# Altium schematic sheet LampOne.SchDoc(LampOne)
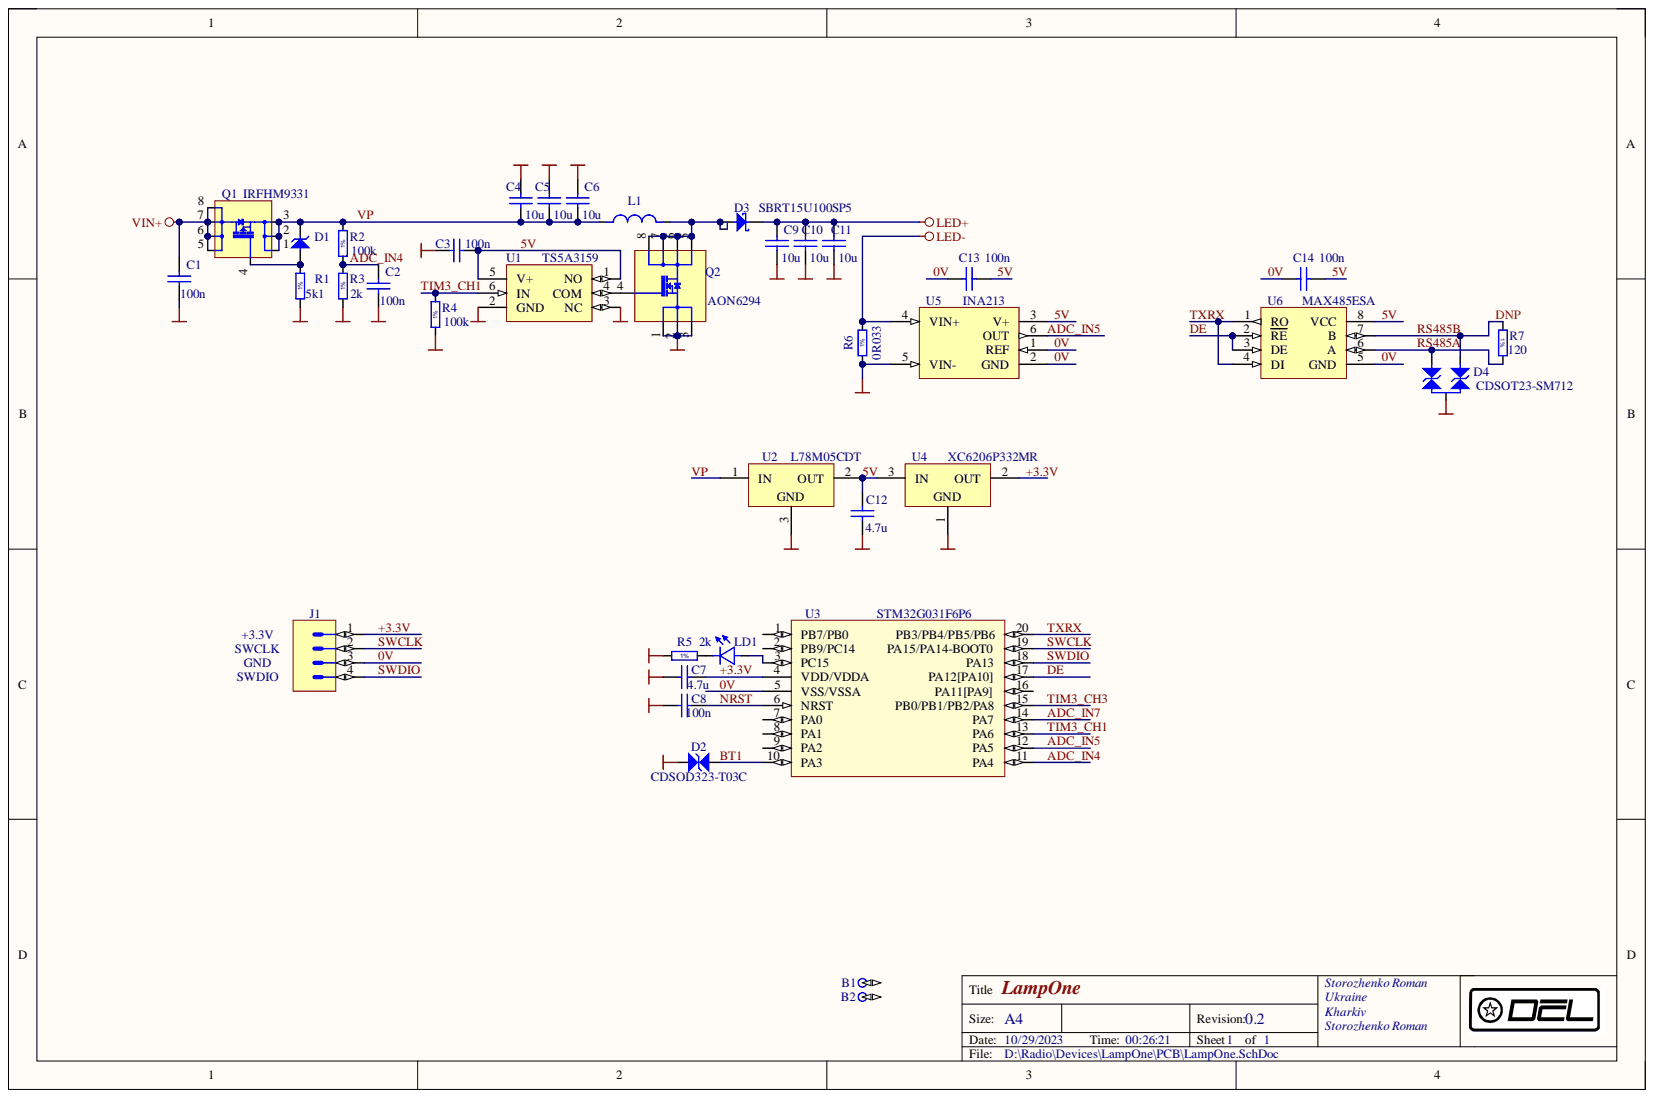
[diagram p0: LampOne.SchDoc]

## Parts
B1  Non Plated Hole  [MTG600H320V8P]  (value not drawn)  (no BOM)
B2  Non Plated Hole  [MTG600H320V8P]  (value not drawn)  (no BOM)
C1  100n  [CAPC1608X87N]
C2  100n  [CAPC1608X87N]
C3  100n  [CAPC1608X87N]
C4  10u  [CAPC3225X270N]
C5  10u  [CAPC3225X270N]
C6  10u  [CAPC3225X270N]
C7  4.7u  [CAPC1608X87N]
C8  100n  [CAPC1608X87N]
C9  10u  [CAPC3225X270N]
C10  10u  [CAPC3225X270N]
C11  10u  [CAPC3225X270N]
C12  4.7u  [CAPC1608X87N]
C13  100n  [CAPC1608X87N]
C14  100n  [CAPC1608X87N]
D1  BZV55-B10  [DIOMELF3515N]  (value not drawn)
D2  CDSOD323-T03C  [SOD2512X110N]
D3  SBRT15U100SP5  [SBRT15U100SP5]
D4  CDSOT23-SM712  [SOT95P240X130-3N]
J1  Header 4  [3M_2304-6111-TG]  (value not drawn)
L1  10uH  [IND_BOURNS_PM127SH]  (value not drawn)
LD1  Red  [LEDC1608X80N_RED]  (value not drawn)
Q1  IRFHM9331  [PQFN50P300X300X100_HS-9N]
Q2  AON6294  [DFN-5X6-8L]
R1  5k1  [RESC1608X55N]
R2  100k  [RESC1608X55N]
R3  2k  [RESC1608X55N]
R4  100k  [RESC1608X55N]
R5  2k  [RESC1608X55N]
R6  0R033  [RESC3216X80N]
R7  120  Mounted: DNP  [RESC1005X40N]
U1  TS5A3159  [SOT65P210X110-6N]
U2  L78M05CDT  [TO228P1010X239-3N-R521X544]
U3  STM32G031F6P6  [SOP65P640X110-20N]
U4  XC6206P332MR  [SOT95P280X115-3N]
U5  INA213  [SOT65P210X110-6N]
U6  MAX485ESA  [SOIC127P600X175-8N]

## Nets
LED+: C9.2 C10.2 C11.2 D3.3
LED-: R6.2 U5.4(VIN+)
VIN+: C1.2 Q1.5 Q1.6 Q1.7 Q1.8
0V: C1.1 C2.1 C3.2 C4.1 C5.1 C6.1 C7.1 C8.1 C9.1 C10.1 C11.1 C12.1 C13.2 C14.2 D2.2 D4.3 J1.3 Q2.1 Q2.2 Q2.3 R1.1 R3.1 R4.1 R5.1 R6.1 U1.2(GND) U1.3(NC) U2.3 U3.5(VSS/VSSA) U4.1 U5.1(REF) U5.2(GND) U5.5(VIN-) U6.5(GND)
5V: C3.1 C12.2 C13.1 C14.1 U1.1(NO) U1.5(V+) U2.2 U4.3 U5.3(V+) U6.8(VCC)
+3.3V: C7.2 J1.1 U3.4(VDD/VDDA) U4.2
ADC_IN4: C2.2 R2.1 R3.2 U3.11(PA4)
ADC_IN5: U3.12(PA5) U5.6(OUT)
ADC_IN7: U3.14(PA7)
BT1: D2.1 U3.10(PA3)
DE: U3.17(PA12[PA10]) U6.2(RE) U6.3(DE)
NRST: C8.2 U3.6(NRST)
RS485A: D4.2 R7.2 U6.6(A)
RS485B: D4.1 R7.1 U6.7(B)
SWCLK: J1.2 U3.19(PA15/PA14-BOOT0)
SWDIO: J1.4 U3.18(PA13)
TIM3_CH1: R4.2 U1.6(IN) U3.13(PA6)
TIM3_CH3: U3.15(PB0/PB1/PB2/PA8)
TXRX: U3.20(PB3/PB4/PB5/PB6) U6.1(RO) U6.4(DI)
VP: C4.2 C5.2 C6.2 D1.1 L1.1 Q1.1 Q1.2 Q1.3 R2.2 U2.1
NetD1_2: D1.2 Q1.4 R1.2
NetD3_1: D3.1 D3.2 L1.2 Q2.5 Q2.6 Q2.7 Q2.8
NetLD1_1: LD1.1 R5.2
NetLD1_2: LD1.2 U3.3(PC15)
NetQ2_4: Q2.4 U1.4(COM)

Not connected: B1 1; B2 1; U3 1(PB7/PB0) 2(PB9/PC14) 7(PA0) 8(PA1) 9(PA2) 16(PA11[PA9])

## Library data of the parts
B1 B2 = NON-PLATED_HOLE3.2_PAD6.0_8p: Single Terminal Socket; Part Description: Through Hole: Hole Dia.=3.2mm Pad Dia.=6mm + 6 Via Dia.=0.3mm; Database Table Name: Pads; Mounted: No; Component Type: Standard (No BOM); ComponentHeight: 0mm; CreateDate: 11/21/2022; Family: Non Plated Hole; LatestRevisionDate: 11/21/2022; Library Name: Eletro-mechanical.DbLib; PackageDescription: Non Plated Through Hole: Hole Dia.=3.2mm; Part Number: NON-PLATED_HOLE3.2_PAD6.0_8p; Pin Count: 1; SMD: No; Socket: No; Status: None; Author: DEL
C1-C3 C8 C13 C14 = CC0603_100NF_50V_10%_X7R: Non-polarised capacitor; Manufacturer: GENERIC; Part Description: SMD Multilayer Chip Ceramic Capacitor; Database Table Name: Capacitors SMD; TC: X7R; Tolerance: ±10%; Voltage: 50V; Case: 0603; Component Kind: Standard; Component Type: Standard; CreateDate: 7/14/2014; LatestRevisionDate: 7/14/2014; Library Name: Capacitors.DbLib; Manufacturer1 ComponentHeight: 0.87mm; Manufacturer Part Number: CC0603_100NF_50V_10%_X7R; Mounted: Yes; Part Number: CC0603_100NF_50V_10%_X7R; Pin Count: 2; SMD: Yes; Socket: No; Status: Preferred; Value: 100n; Author: Elman
C4-C6 C9-C11 = CC1210_10UF_25V_10%_X7R: Non-polarised capacitor; Manufacturer: GENERIC; Manufacturer1 Part Number: C1210C106K3RAC; Manufacturer Part Number: CC1210_10UF_50V_10%_X7R; Part Description: SMD Multilayer Chip Ceramic Capacitor; Database Table Name: Capacitors SMD; TC: X7R; Tolerance: ±10%; Voltage: 50V; Case: 1210; Component Kind: Standard; Component Type: Standard; CreateDate: 5/5/2009; HelpURL: \\cern.ch\dfs\Applications\Altium\Datasheets\CC1210_X7R_KEMET.pdf; LatestRevisionDate: 4/1/2010; Library Name: Capacitors.DbLib; Manufacturer1 ComponentHeight: 2.7mm; Manufacturer1 Example: KEMET; Mounted: Yes; Part Number: CC1210_10UF_50V_10%_X7R; Pin Count: 2; SMD: Yes; Socket: No; Status: None; Value: 10u; Author: CERN DEM JLC
C7 C12 = CC0603_4.7UF_10V_10%_X5R: Non-polarised capacitor; Manufacturer: GENERIC; Manufacturer1 Part Number: C1608X5R1A475K080AC; Part Description: SMD Multilayer Chip Ceramic Capacitor; Database Table Name: Capacitors SMD; TC: X5R; Tolerance: ±10%; Voltage: 10V; Case: 0603; Component Kind: Standard; Component Type: Standard; CreateDate: 11/13/2016; LatestRevisionDate: 11/13/2016; Library Name: Capacitors.DbLib; Manufacturer1 ComponentHeight: 0.95mm; Manufacturer1 Example: TDK; Manufacturer Part Number: CC0603_4.7uF_10V_10%_X5R; Mounted: Yes; Part Number: CC0603_4.7UF_10V_10%_X5R; Pin Count: 2; SMD: Yes; Socket: No; Status: Preferred; Value: 4.7u; Author: ELMAN
D1 = BZV55-B10: Zener Diode; Manufacturer: PHILIPS NXP; Part Description: Voltage Regulator Diode; Database Table Name: Diodes; Power: 500mW; Voltage: 10V; Case: SOD-80C; Component Kind: Standard; Component Type: Standard; ComponentHeight: 1.6mm; CreateDate: 5/6/2008; Device: BZV55-B10; HelpURL: \\cern.ch\dfs\Applications\Altium\Datasheets\BZV55.pdf; LatestRevisionDate: 5/6/2008; Library Name: ICs And Semiconductors.DbLib; Manufacturer Part Number: BZV55-B10; Mounted: Yes; PackageDescription: SOD80C, MELF Diode, 2-Leads, Body 3.5x1.5mm, IPC Medium Density; Part Number: BZV55-B10; Pin Count: 2; SMD: Yes; Socket: No; Author: CERN DEM JLC
D2 = CDSOD323-T03C: Transient Voltage Suppressor Diode (Bi-Directional); Manufacturer: BOURNS; Part Description: TVS Diode; Database Table Name: Diodes; Power: 20A; Voltage: 4V; Case: SOD323; Component Kind: Standard; Component Type: Standard; ComponentHeight: 1.1mm; CreateDate: 11/21/2022; Device: CDSOD323-T03C; LatestRevisionDate: 11/21/2022; Library Name: ICs And Semiconductors.DbLib; Manufacturer Part Number: CDSOD323-T03C; Mounted: Yes; PackageDescription: SOD-323, 1.70mm W X 1.25mm L X 1.10mm H; Part Number: CDSOD323-T03C; Pin Count: 3; SMD: Yes; Socket: No; Author: DEL
D3 = SBRT15U100SP5: Diode  Schottky Anode pin 1 and 2 cathode pin 3; Part Description: Schottky Rectifier; Database Table Name: Diodes; Power: 15A; Voltage: 100V; Case: DFN; Component Kind: Standard; Component Type: Standard; ComponentHeight: 1.15mm; CreateDate: 6/24/2022; Device: SBRT15U100SP5; LatestRevisionDate: 6/24/2022; Library Name: ICs And Semiconductors.DbLib; Manufacturer: DIODES; Manufacturer Part Number: SBRT15U100SP5; Mounted: Yes; PackageDescription: DFN, 3-Leads, Body 4.05x6.6mm; Part Number: SBRT15U100SP5; Pin Count: 3; SMD: Yes; Socket: No; Author: DEL
D4 = CDSOT23-SM712: Transient Voltage Suppressor Diode (Bi-Directional); Manufacturer: BOURNS; Part Description: TVS Diode; Database Table Name: Diodes; Power: 17A; Voltage: 7.5V; Case: SOT23-3; Component Kind: Standard; Component Type: Standard; ComponentHeight: 1.3mm; CreateDate: 1/27/2022; Device: CDSOT23-SM712; LatestRevisionDate: 1/27/2022; Library Name: ICs And Semiconductors.DbLib; Manufacturer Part Number: CDSOT23-SM712; Mounted: Yes; PackageDescription: SOT23 3-Leads, Pitch 0.95mm - Body 1.3x2.9mm, IPC Medium Density; Part Number: CDSOT23-SM712; Pin Count: 3; SMD: Yes; Socket: No; Author: DEL
J1 = 3M_2304-6111-TG: Connector 4 Male; Part Description: 4 Contacts - Vertival Contacts Straight Strip Header Pitch 2.54; Database Table Name: 3M; Component Kind: Standard; Component Type: Standard; ComponentHeight: 8.4mm; CreateDate: 2018-09-13; Family: Header 4; LatestRevisionDate: 2018-09-13; Library Name: Connectors.DbLib; Manufacturer: 3M; Manufacturer Part Number: 3M_2304-6111-TG; Mounted: Yes; Part Number: 3M_2304-6111-TG; Pin Count: 4; SMD: No; Socket: No; Status: None; Author: DEL
L1 = IND_4.7UH_20%_SUMIDA_CDRH124: Inductor; Manufacturer: SUMIDA; Manufacturer Part Number: CDRH125NP-10R; Part Description: Shielded SMD Power Inductors CDRH125 Series; Part Number: IND_10UH_20%_SUMIDA_CDRH125; Database Table Name: Inductors SMD; Power: 4A; Resistance: 0.018R; Tolerance: ±20%; Component Kind: Standard; Component Type: Standard; ComponentHeight: 4.5mm; CreateDate: 11/18/2009; HelpURL: \\cern.ch\dfs\Applications\Altium\Datasheets\IND_BOURNS_PM127SH.pdf; LatestRevisionDate: 11/18/2009; Library Name: Inductors & Transformers.DbLib; Mounted: Yes; Pin Count: 2; SMD: Yes; Socket: No; Status: None; Value: 10uH; Author: CERN DEM JLC
LD1 = LED_ROHM_SML-311UTT86K: LED 1C 2A; Manufacturer: ROHM; Part Description: Low current chip LED SML-311 Serie; Database Table Name: LEDs & Displays; Color: Red; Component Kind: Standard; Component Type: Standard; ComponentHeight: 0.8mm; CreateDate: 3/16/2010; HelpURL: \\cern.ch\dfs\Applications\Altium\Datasheets\LED_ROHM_SML-311UTT86K.pdf; LatestRevisionDate: 3/17/2010; Library Name: ICs And Semiconductors.DbLib; Manufacturer Part Number: SML-311UTT86K; Mounted: Yes; PackageDescription: Chip Red LED, 2-Leads, Body 1.6x0.8mm, IPC Medium Density; Part Number: LED_ROHM_SML-311UTT86K; Pin Count: 2; SMD: Yes; Socket: No; Author: CERN DEM JLC
Q1 = IRFHM9331TRPbF: P-CHANNEL MOSFET WITH DIODE; Manufacturer: INFINEON; Part Description: -30V 11A 0.014 Ohm P-Channel  MOSFET; Database Table Name: Transistors; Case: PQFN; Component Kind: Standard; Component Type: Standard; ComponentHeight: 1mm; CreateDate: 29.01.2018; Device: IRFHM9331; LatestRevisionDate: 29.01.2018; Library Name: ICs And Semiconductors.DbLib; Manufacturer Part Number: IRFHM9331TRPbF; Mounted: Yes; PackageDescription: PQFN, 8-Leads, Body 3,00x3,00mm, Pitch 0,50mm, Thermal Pad 4,00x2,20mm, IPC Medium Density; Part Number: IRFHM9331TRPbF; Pin Count: 8; SMD: Yes; Socket: No; Author: DEL
Q2 = AON6294: Manufacturer: LPHA & OMEGA SEMICONDUCTOR; Part Description: 100V N-Channel AlphaMOS; Database Table Name: Transistors; Case: DFN-5X6; Component Kind: Standard; Component Type: Standard; ComponentHeight: 1mm; CreateDate: 6/24/2022; Device: AON6294; LatestRevisionDate: 6/24/2022; Library Name: ICs And Semiconductors.DbLib; Manufacturer Part Number: AON6294; Mounted: Yes; PackageDescription: DFN 5x6; Part Number: AON6294; Pin Count: 8; SMD: Yes; Socket: No; Author: DEL
R1 = R0603_5K1_1%_0.1W_100PPM: Resistor - 1%; Manufacturer: GENERIC; Manufacturer1 Part Number: NRC06F5101TRF; Part Description: General Purpose Thick Film Chip Resistor; Database Table Name: Resistors SMD; Power: 0.1W; TC: ±100ppm/°C; Case: 0603; Component Kind: Standard; Component Type: Standard; CreateDate: 12/3/2007; HelpURL: \\cern.ch\dfs\Applications\Altium\Datasheets\R0603_NIC_NRC.pdf; LatestRevisionDate: 3/13/2008; Library Name: Resistors.DbLib; Manufacturer1 ComponentHeight: 0.55mm; Manufacturer1 Example: NIC COMPONENT; Manufacturer Part Number: R0603_5K1_1%_0.1W_100PPM; Mounted: Yes; Part Number: R0603_5K1_1%_0.1W_100PPM; Pin Count: 2; SMD: Yes; Socket: No; Status: Preferred; Tolerance: ±1%; Value: 5k1; Author: CERN DEM JLC
R2 R4 = R0603_100K_1%_0.1W_100PPM: Resistor - 1%; Manufacturer: GENERIC; Manufacturer1 Part Number: NRC06F1003TRF; Part Description: General Purpose Thick Film Chip Resistor; Database Table Name: Resistors SMD; Power: 0.1W; TC: ±100ppm/°C; Case: 0603; Component Kind: Standard; Component Type: Standard; CreateDate: 12/3/2007; HelpURL: \\cern.ch\dfs\Applications\Altium\Datasheets\R0603_NIC_NRC.pdf; LatestRevisionDate: 3/13/2008; Library Name: Resistors.DbLib; Manufacturer1 ComponentHeight: 0.55mm; Manufacturer1 Example: NIC COMPONENT; Manufacturer Part Number: R0603_100K_1%_0.1W_100PPM; Mounted: Yes; Part Number: R0603_100K_1%_0.1W_100PPM; Pin Count: 2; SMD: Yes; Socket: No; Status: Preferred; Tolerance: ±1%; Value: 100k; Author: CERN DEM JLC
R3 R5 = R0603_2K_1%_0.1W_100PPM: Resistor - 1%; Manufacturer: GENERIC; Manufacturer1 Part Number: NRC06F2001TRF; Part Description: General Purpose Thick Film Chip Resistor; Database Table Name: Resistors SMD; Power: 0.1W; TC: ±100ppm/°C; Case: 0603; Component Kind: Standard; Component Type: Standard; CreateDate: 12/3/2007; HelpURL: \\cern.ch\dfs\Applications\Altium\Datasheets\R0603_NIC_NRC.pdf; LatestRevisionDate: 3/13/2008; Library Name: Resistors.DbLib; Manufacturer1 ComponentHeight: 0.55mm; Manufacturer1 Example: NIC COMPONENT; Manufacturer Part Number: R0603_2K_1%_0.1W_100PPM; Mounted: Yes; Part Number: R0603_2K_1%_0.1W_100PPM; Pin Count: 2; SMD: Yes; Socket: No; Status: Preferred; Tolerance: ±1%; Value: 2k; Author: CERN DEM JLC
R6 = R1206_0R033_1%_0.25W_100PPM: Resistor - 1%; Manufacturer: YAGEO; Manufacturer Part Number: RC1206FR-0733RL; Part Description: Low Value Flat Chip Resistor; Database Table Name: Resistors SMD; Power: 0.25W; TC: ±100ppm/°C; Case: 1206; Component Kind: Standard; Component Type: Standard; ComponentHeight: 0.65mm; CreateDate: 10/28/2023; LatestRevisionDate: 10/28/2023; Library Name: Resistors.DbLib; Mounted: Yes; Part Number: R1206_0R033_1%_0.25W_100PPM; Pin Count: 2; SMD: Yes; Socket: No; Tolerance: ±1%; Value: 0R033; Author: DEL
R7 = R0402_120R_1%_0.0625W_100PPM: Resistor - 1%; Manufacturer: GENERIC; Manufacturer1 Part Number: 232270671201; Part Description: General Purpose Thick Film Chip Resistor; Database Table Name: Resistors SMD; TC: ±100ppm/°C; Case: 0402; Component Kind: Standard; Component Type: Standard; CreateDate: 12/3/2007; HelpURL: \\cern.ch\dfs\Applications\Altium\Datasheets\R0402_Phycomp_RC0402.pdf; LatestRevisionDate: 3/13/2008; Library Name: Resistors.DbLib; Manufacturer1 ComponentHeight: 0.4mm; Manufacturer1 Example: PHYCOMP; Manufacturer Part Number: R0402_120R_1%_0.0625W_100PPM; Part Number: R0402_120R_1%_0.0625W_100PPM; Pin Count: 2; Power: 0.0625W; SMD: Yes; Socket: No; Status: Not recommended; Tolerance: ±1%; Value: 120; Author: CERN DEM JLC
U1 = TS5A3159DCKR: 1-Ω SPDT Analog Switch; Manufacturer: TEXAS INSTRUMENTS; Manufacturer Part Number: ADS1271IBPW; Database Table Name: Analog & Interface; Case: SC70-6; Component Kind: Standard; Component Type: Standard; ComponentHeight: 1.1mm; CreateDate: 6/20/2022; Device: TS5A3159; LatestRevisionDate: 6/20/2022; Library Name: ICs And Semiconductors.DbLib; Mounted: Yes; PackageDescription: SC70-6, SOT, 0.65mm Pitch, 6 pin; Part Description: 1-Ω SPDT Analog Switch; Part Number: TS5A3159DCKR; Pin Count: 6; SMD: Yes; Socket: No; Author: DEL
U2 = L78M05CDT-TR: 3-Terminal Regulator 1=IN 2=OUT 3=GND; Manufacturer: ST MICROELECTRONICS; Manufacturer Part Number: LM7805CT; Part Description: 3-Terminal 5V 0.5A Positive Voltage Regulator; Database Table Name: Regulators; Case: DPAK; Component Kind: Standard; Component Type: Standard; ComponentHeight: 2.39mm; CreateDate: 12/13/2017; Device: L78M05CDT; LatestRevisionDate: 12/13/2017; Library Name: ICs And Semiconductors.DbLib; Mounted: Yes; PackageDescription: TO-252 DPAK TO, 2.28mm pitch; 2 pin, 10.105mm L X 6.095mm W X 2.39mm H body, IPC Medium Density; Part Number: L78M05CDT-TR; Pin Count: 3; SMD: No; Socket: No; Author: DEL
U3 = STM32G031F6P6: Arm® Cortex®-M0+ 32-bit MCU; Manufacturer: ST Microelectronics; Manufacturer Part Number: STM32F030F4P6; Case: TSSOP20; Component Kind: Standard; Component Type: Standard; ComponentHeight: 1.2mm; CreateDate: 1/26/2022; Database Table Name: MCU; Device: STM32G031F6P6; LatestRevisionDate: 1/26/2022; Library Name: ICs And Semiconductors.DbLib; Mounted: Yes; PackageDescription: SOP, 20-Leads, Body 6.6x4.5mm (max), Pitch 0.65mm, IPC Medium Density; Part Description: Arm® Cortex®-M0+ 32-bit MCU; Part Number: STM32G031F6P6; Pin Count: 20; SMD: Yes; Socket: No; Author: DEL
U4 = XC6206P332MR: 3-Terminal Regulator 1=GND 2=OUT 3=IN; Manufacturer: TOREX; Part Description: 3-Terminal 3.3V 200mA Positive Voltage Regulator; Database Table Name: Regulators; Case: SOT23; Component Kind: Standard; Component Type: Standard; ComponentHeight: 1.1mm; CreateDate: 1/27/2022; Device: XC6206P332MR; LatestRevisionDate: 1/27/2022; Library Name: ICs And Semiconductors.DbLib; Manufacturer Part Number: SOT95P280X115-3N; Mounted: Yes; PackageDescription: SOT23 3-Leads, Pitch 0.95mm - Body 1.3x2.9mm, IPC Medium Density; Part Number: XC6206P332MR; Pin Count: 3; SMD: Yes; Socket: No; Author: DEL
U5 = INA213xID: INA21x Voltage Output, Low- or High-Side Measurement, Bidirectional, Zero-Drift Series, Current-Shunt Monitors. Wide Common-Mode Range: –0.3 V to 26 V.; Manufacturer: TEXAS INSTRUMENTS; Manufacturer Part Number: INA213AIDCKT; Part Description: Current-Shunt Monitors,  –0.3 V to 26 V; Database Table Name: Analog & Interface; Case: SC70-6; Component Kind: Standard; Component Type: Standard; ComponentHeight: 1.1mm; CreateDate: 10/9/2017; Device: INA213; LatestRevisionDate: 10/9/2017; Library Name: ICs And Semiconductors.DbLib; Mounted: Yes; PackageDescription: SOT363, SC70-6, SOT, 0.65mm Pitch, 6 pin, 1.25mm W X 2.00mm L X 1.10mm H, IPC Medium Density; Part Number: INA213xID; Pin Count: 6; SMD: Yes; Socket: No; Author: DEL
U6 = MAX485ESA: Low-Power, Slew-Rate-Limited  RS-485/RS-422 Transceivers; Manufacturer: MAXIM; Database Table Name: Analog & Interface; Case: SOIC8; Component Kind: Standard; Component Type: Standard; ComponentHeight: 1.75mm; CreateDate: 1/20/2020; Device: MAX485ESA; LatestRevisionDate: 1/20/2020; Library Name: ICs And Semiconductors.DbLib; Manufacturer Part Number: MAX485ESA; Mounted: Yes; PackageDescription: SOIC 8, Pitch 1.27mm - Body 4x5mm, IPC Medium Density; Part Description: Low-Power, Slew-Rate-Limited RS-485/RS-422 Transceivers; Part Number: MAX485ESA; Pin Count: 8; SMD: Yes; Socket: No; Author: DEL
